# Altium schematic sheet Lcd.SchDoc
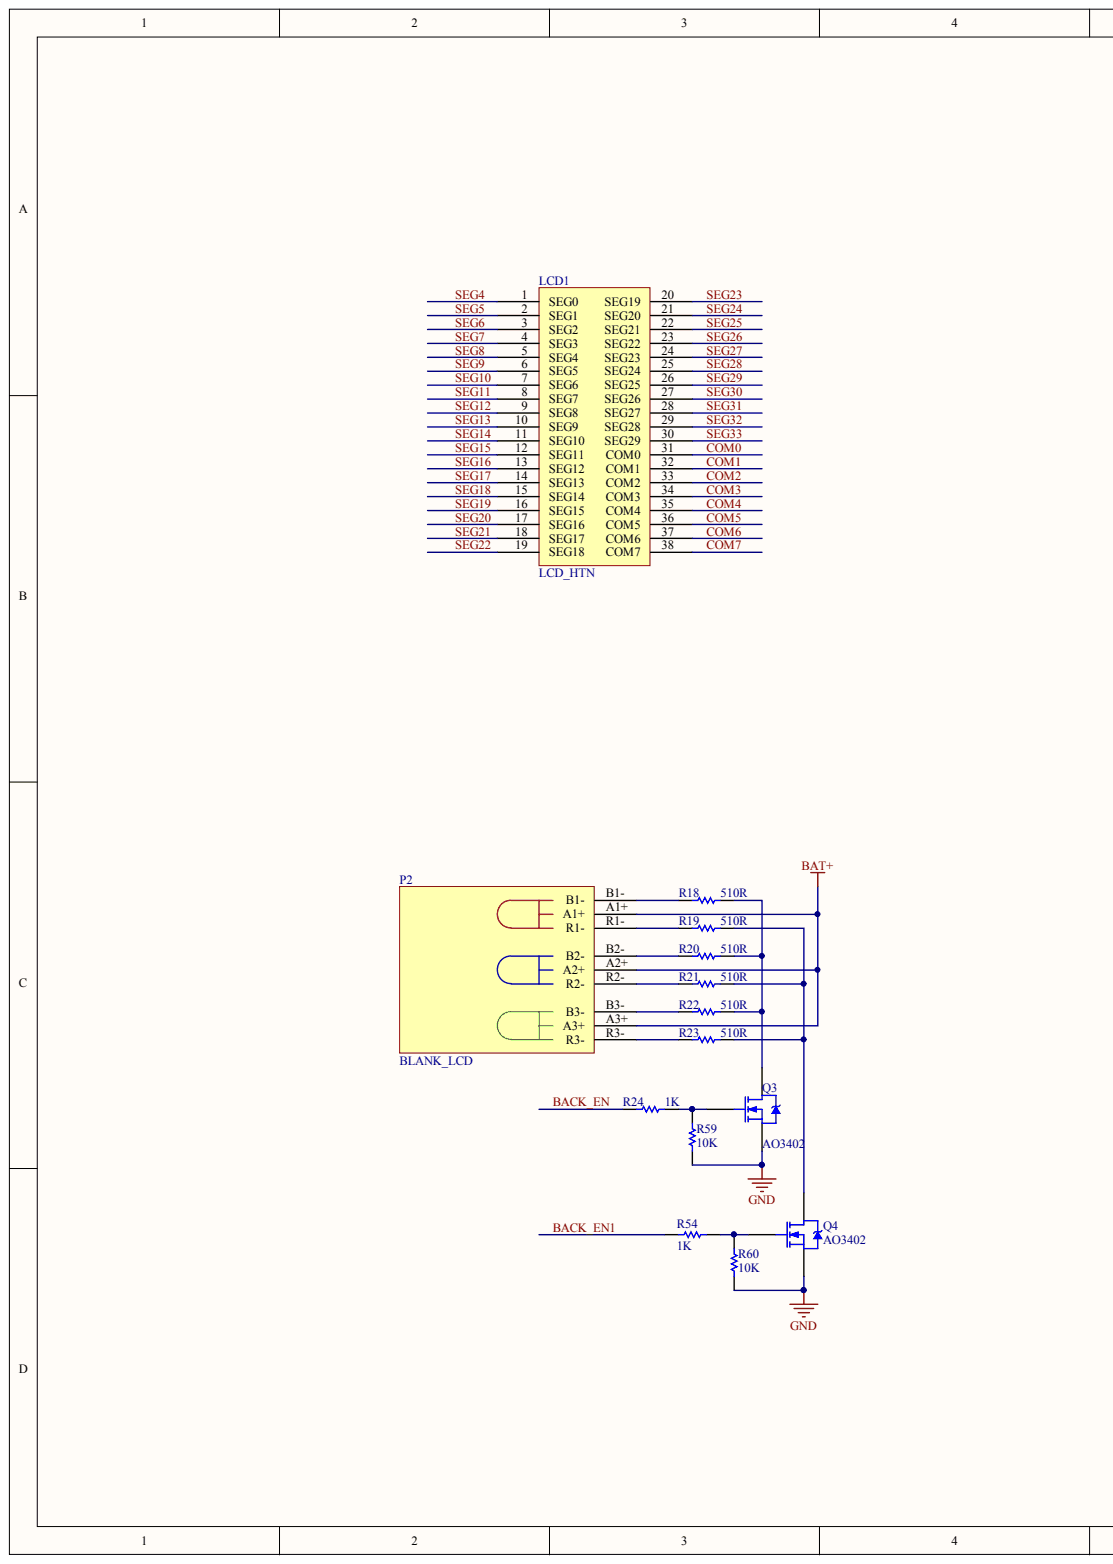
[diagram p0: Lcd.SchDoc, left]
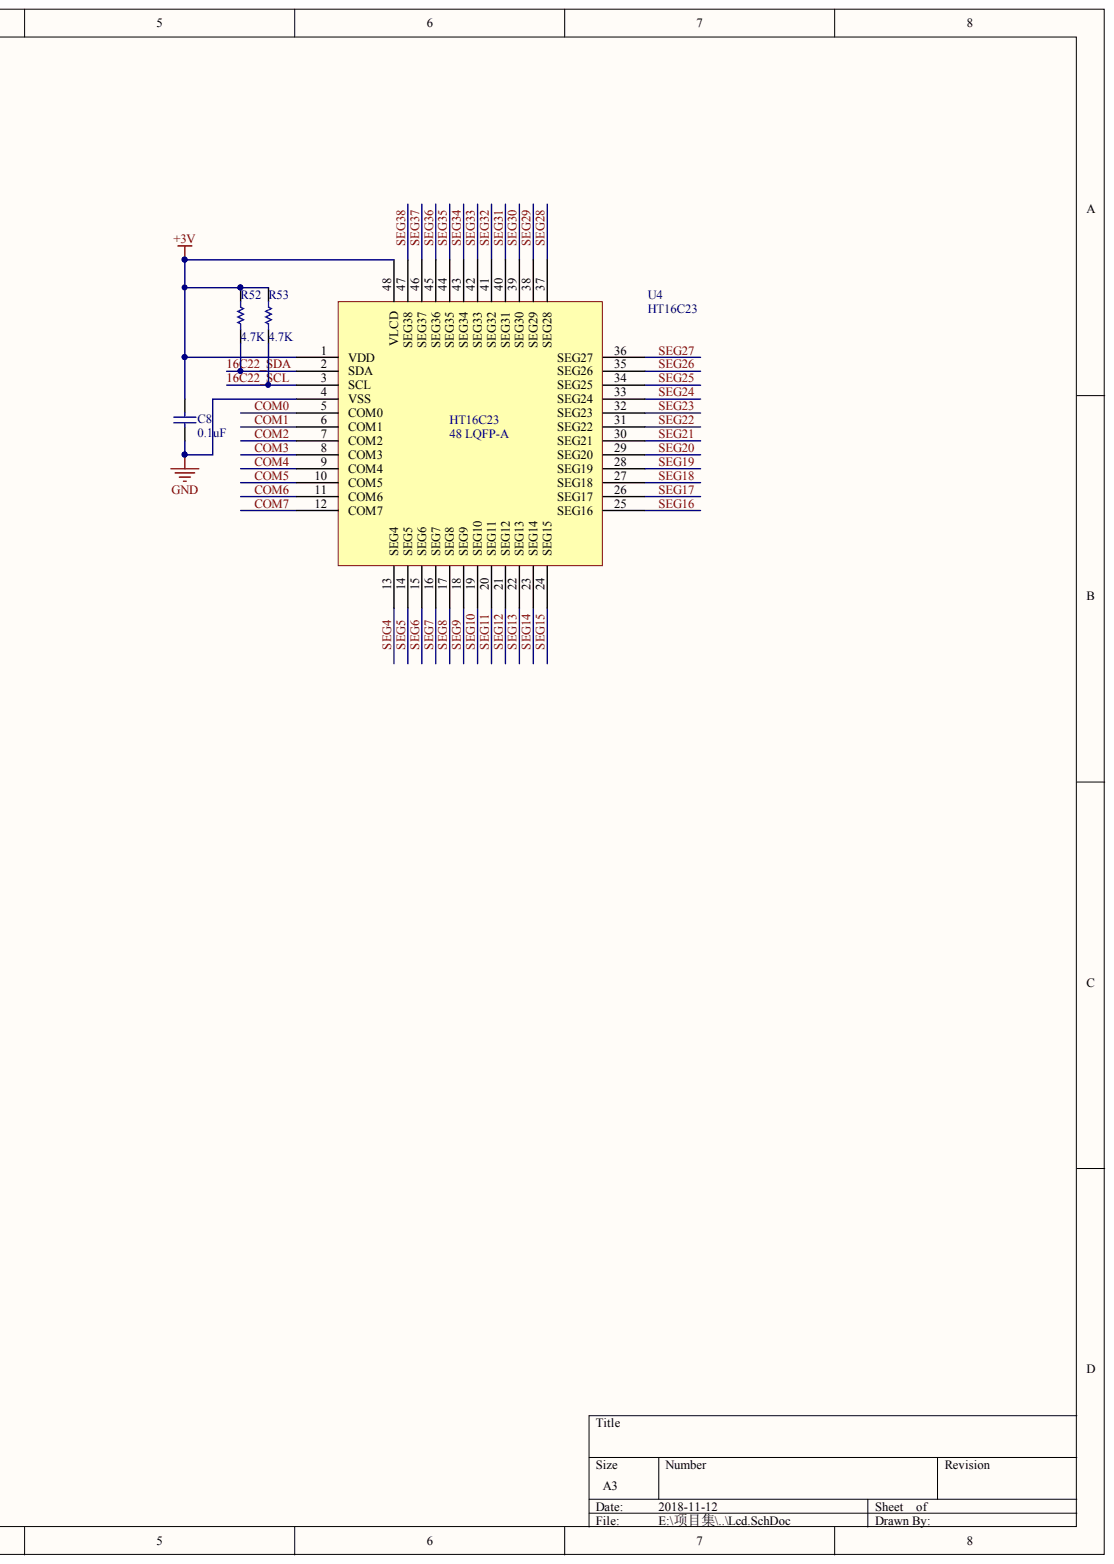
[diagram p1: Lcd.SchDoc, right]

## Parts
C8  0.1uF  [0603C]
LCD1  LCD_HTN  [HTN_LCD]
P2  BLANK_LCD  [Backlight]
Q3  AO3402  [SOT-23]
Q4  AO3402  [SOT-23]
R18  510R  [0603R]
R19  510R  [0603R]
R20  510R  [0603R]
R21  510R  [0603R]
R22  510R  [0603R]
R23  510R  [0603R]
R24  1K  [0603R]
R52  4.7K  [0603R]
R53  4.7K  [0603R]
R54  1K  [0603R]
R59  10K  [0603R]
R60  10K  [0603R]
U4  HT16C23  [lqfp48]

## Nets
+3V: C8.2 R52.1 R53.1 U4.1(VDD) U4.48(VLCD)
BAT+: P2.A1+ P2.A2+ P2.A3+
GND: C8.1 Q3.2 Q4.2 R59.2 R60.2 U4.4(VSS)
16C22_SCL: R53.2 U4.3(SCL)
16C22_SDA: R52.2 U4.2(SDA)
BACK_EN: R24.2
BACK_EN1: R54.1
COM0: LCD1.31(COM0) U4.5(COM0)
COM1: LCD1.32(COM1) U4.6(COM1)
COM2: LCD1.33(COM2) U4.7(COM2)
COM3: LCD1.34(COM3) U4.8(COM3)
COM4: LCD1.35(COM4) U4.9(COM4)
COM5: LCD1.36(COM5) U4.10(COM5)
COM6: LCD1.37(COM6) U4.11(COM6)
COM7: LCD1.38(COM7) U4.12(COM7)
SEG4: LCD1.1(SEG0) U4.13(SEG4)
SEG5: LCD1.2(SEG1) U4.14(SEG5)
SEG6: LCD1.3(SEG2) U4.15(SEG6)
SEG7: LCD1.4(SEG3) U4.16(SEG7)
SEG8: LCD1.5(SEG4) U4.17(SEG8)
SEG9: LCD1.6(SEG5) U4.18(SEG9)
SEG10: LCD1.7(SEG6) U4.19(SEG10)
SEG11: LCD1.8(SEG7) U4.20(SEG11)
SEG12: LCD1.9(SEG8) U4.21(SEG12)
SEG13: LCD1.10(SEG9) U4.22(SEG13)
SEG14: LCD1.11(SEG10) U4.23(SEG14)
SEG15: LCD1.12(SEG11) U4.24(SEG15)
SEG16: LCD1.13(SEG12) U4.25(SEG16)
SEG17: LCD1.14(SEG13) U4.26(SEG17)
SEG18: LCD1.15(SEG14) U4.27(SEG18)
SEG19: LCD1.16(SEG15) U4.28(SEG19)
SEG20: LCD1.17(SEG16) U4.29(SEG20)
SEG21: LCD1.18(SEG17) U4.30(SEG21)
SEG22: LCD1.19(SEG18) U4.31(SEG22)
SEG23: LCD1.20(SEG19) U4.32(SEG23)
SEG24: LCD1.21(SEG20) U4.33(SEG24)
SEG25: LCD1.22(SEG21) U4.34(SEG25)
SEG26: LCD1.23(SEG22) U4.35(SEG26)
SEG27: LCD1.24(SEG23) U4.36(SEG27)
SEG28: LCD1.25(SEG24) U4.37(SEG28)
SEG29: LCD1.26(SEG25) U4.38(SEG29)
SEG30: LCD1.27(SEG26) U4.39(SEG30)
SEG31: LCD1.28(SEG27) U4.40(SEG31)
SEG32: LCD1.29(SEG28) U4.41(SEG32)
SEG33: LCD1.30(SEG29) U4.42(SEG33)
SEG34: U4.43(SEG34)
SEG35: U4.44(SEG35)
SEG36: U4.45(SEG36)
SEG37: U4.46(SEG37)
SEG38: U4.47(SEG38)
NetP2_B1-: P2.B1- R18.2
NetP2_B2-: P2.B2- R20.2
NetP2_B3-: P2.B3- R22.2
NetP2_R1-: P2.R1- R19.2
NetP2_R2-: P2.R2- R21.2
NetP2_R3-: P2.R3- R23.2
NetQ3_1: Q3.1 R24.1 R59.1
NetQ3_3: Q3.3 R18.1 R20.1 R22.1
NetQ4_1: Q4.1 R54.2 R60.1
NetQ4_3: Q4.3 R19.1 R21.1 R23.1

## Library data of the parts
C8 = Cap: Capacitor; Value: 100pF; Component Kind: Standard; LatestRevisionDate: 17-Jul-2002; LatestRevisionNote: Re-released for DXP Platform.; Library Name: Miscellaneous Devices.IntLib; PackageReference: RAD-0.3; Published: 8-Jun-2000; Simulation: CAP; Publisher: Altium Limited
Q3 Q4 = NMOS: Component Kind: Standard; Library Name: My Devices.SCHLIB
R18-R23 R52 R53 R59 R60 = Res1: Resistor; Value: 1K; Component Kind: Standard; LatestRevisionDate: 17-Jul-2002; LatestRevisionNote: Re-released for DXP Platform.; Library Name: Miscellaneous Devices.IntLib; PackageReference: AXIAL-0.3; Published: 8-Jun-2000; Simulation: RESISTOR; Publisher: Altium Limited
R24 R54 = Res1: Resistor; Component Kind: Standard; LatestRevisionDate: 17-Jul-2002; LatestRevisionNote: Re-released for DXP Platform.; Library Name: Miscellaneous Devices.IntLib; PackageReference: AXIAL-0.3; Published: 8-Jun-2000; Simulation: RESISTOR; Value: 1K; Publisher: Altium Limited
LCD1 = LCD_HTN: Component Kind: Standard; Library Name: My Devices.SCHLIB
P2 = BLANK_LCD: Component Kind: Standard; Library Name: My Devices.SCHLIB
U4 = HT16C23: Component Kind: Standard; Library Name: My Devices.SCHLIB
